# Altium schematic sheet AFE.SchDoc
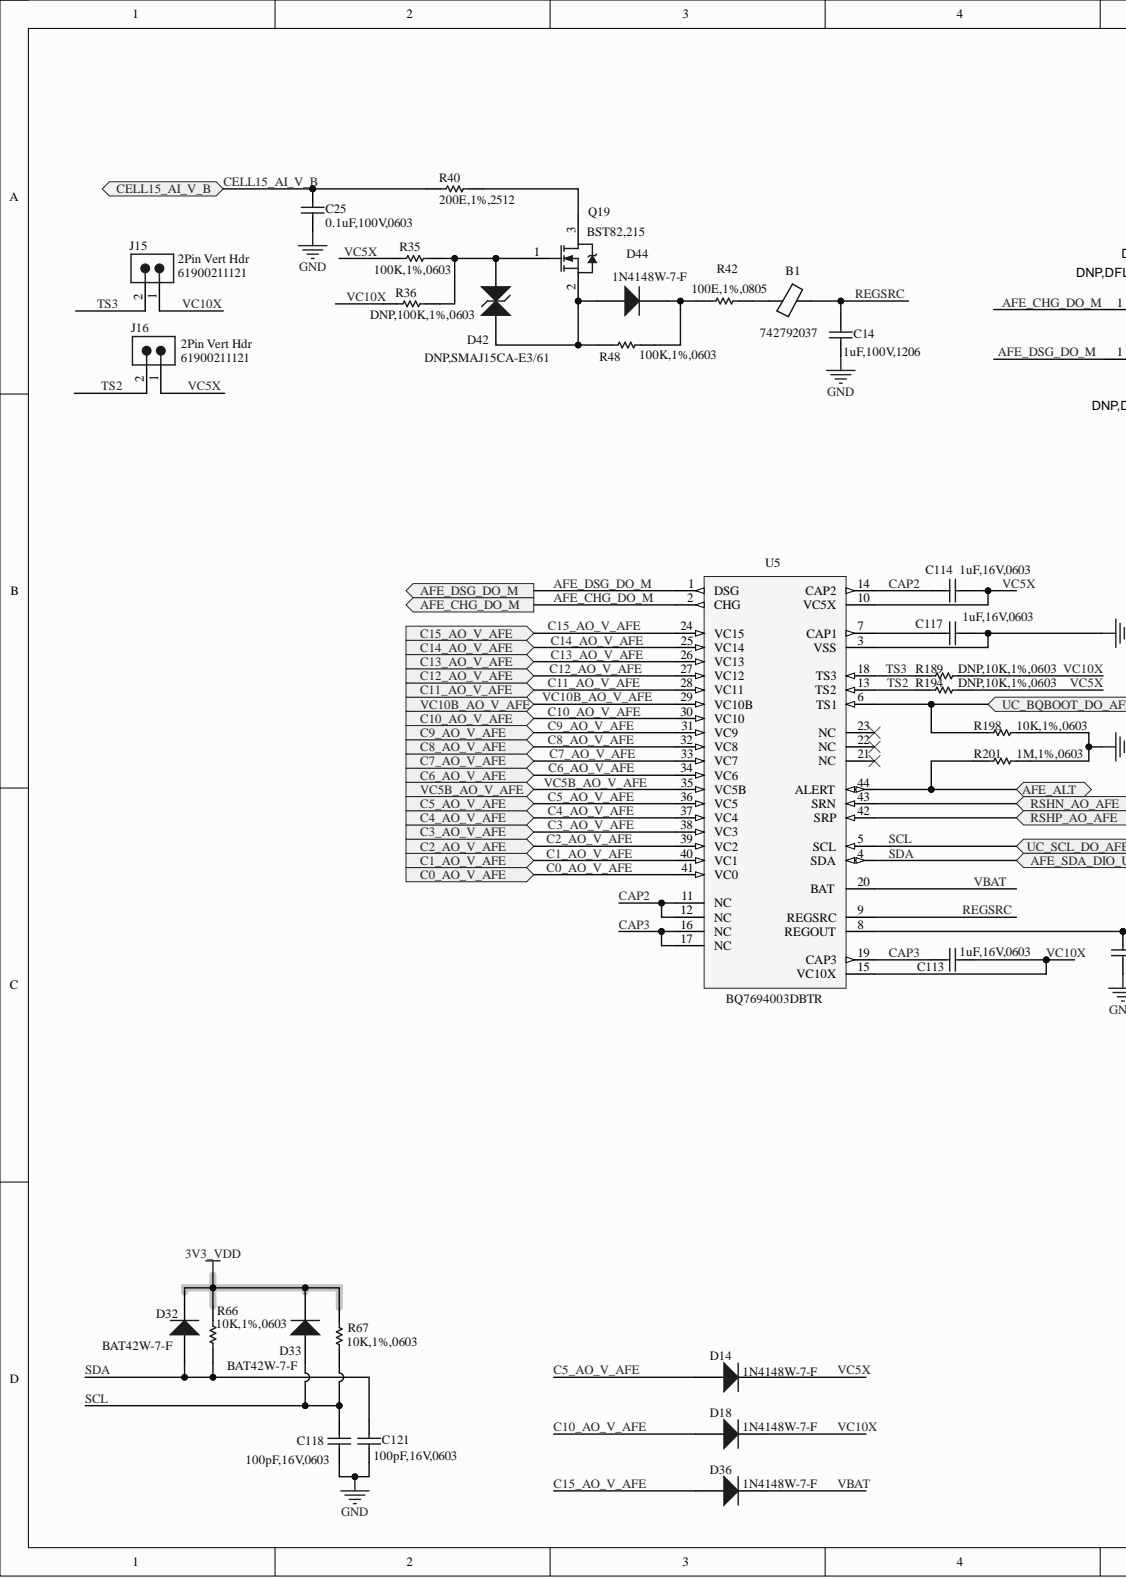
[diagram p0: AFE.SchDoc, left]
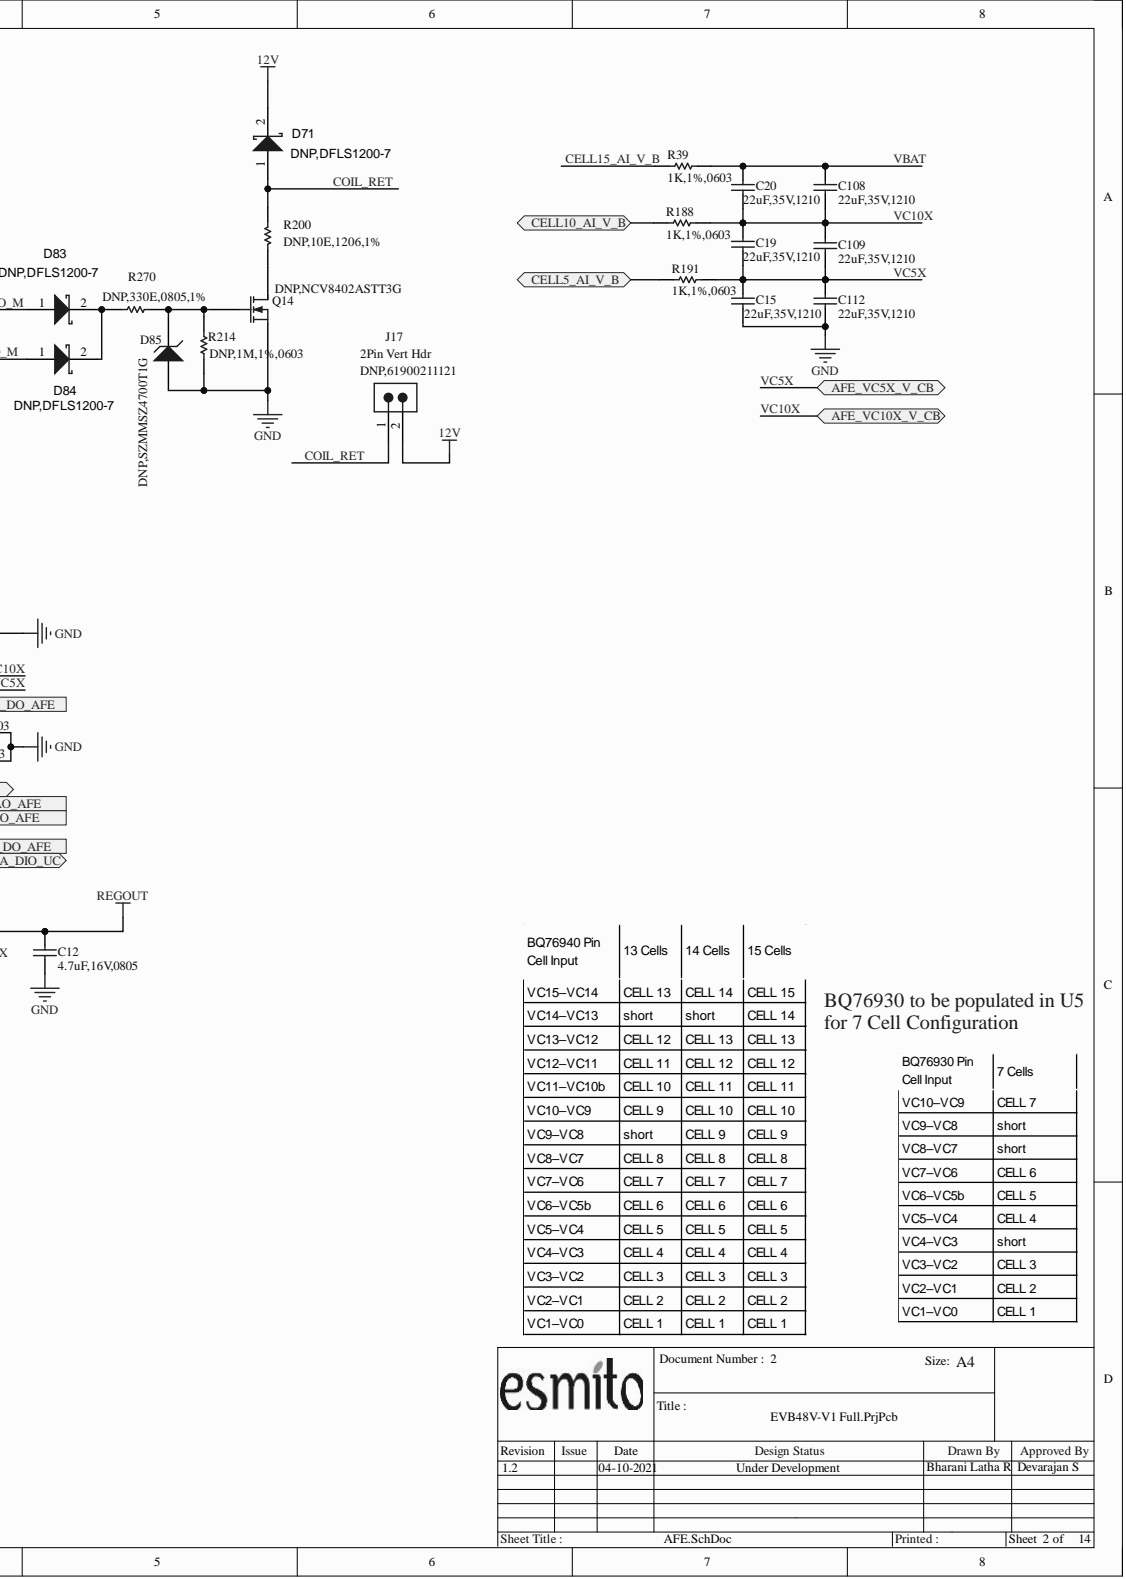
[diagram p1: AFE.SchDoc, right]

## Parts
B1  value 742792037  Part Number: 742792037  [0805_R_M]  (hidden comment: B_LowQ)
C12  value 4.7uF,16V,0805  [0805_R_M]  (hidden comment: C_Semi)
C14  value 1uF,100V,1206  [1206_C_M]  (hidden comment: C_Semi)
C15  value 22uF,35V,1210  [1210_R_M]  (hidden comment: C_Semi)
C19  value 22uF,35V,1210  [1210_R_M]  (hidden comment: C_Semi)
C20  value 22uF,35V,1210  [1210_R_M]  (hidden comment: C_Semi)
C25  value 0.1uF,100V,0603  [0603_C_M]  (hidden comment: C_Semi)
C108  value 22uF,35V,1210  [1210_R_M]  (hidden comment: C_Semi)
C109  value 22uF,35V,1210  [1210_R_M]  (hidden comment: C_Semi)
C112  value 22uF,35V,1210  [1210_R_M]  (hidden comment: C_Semi)
C113  value 1uF,16V,0603  [0603_C_M]  (hidden comment: C_Semi)
C114  value 1uF,16V,0603  [0603_C_M]  (hidden comment: C_Semi)
C117  value 1uF,16V,0603  [0603_C_M]  (hidden comment: C_Semi)
C118  value 100pF,16V,0603  [0603_C_M]  (hidden comment: C_Semi)
C121  value 100pF,16V,0603  [0603_C_M]  (hidden comment: C_Semi)
D14  value 1N4148W-7-F  Part Number: 1N4148W-7-F  [SOD_123_M]  (hidden comment: D_Standard)
D18  value 1N4148W-7-F  Part Number: 1N4148W-7-F  [SOD_123_M]  (hidden comment: D_Standard)
D32  value BAT42W-7-F  Part Number: BAT42W-7-F  [SOD_123_M]  (hidden comment: D_Standard)
D33  value BAT42W-7-F  Part Number: BAT42W-7-F  [SOD_123_M]  (hidden comment: D_Standard)
D36  value 1N4148W-7-F  Part Number: 1N4148W-7-F  [SOD_123_M]  (hidden comment: D_Standard)
D42  SMAJ15CA-E3/61  value DNP,SMAJ15CA-E3/61  [DIOM5127X229N]
D44  value 1N4148W-7-F  Part Number: 1N4148W-7-F  [SOD_123_M]  (hidden comment: D_Standard)
D71  DFLS1200-7  value DNP,DFLS1200-7  [POWERDI123]
D83  DFLS1200-7  value DNP,DFLS1200-7  [POWERDI123]
D84  DFLS1200-7  value DNP,DFLS1200-7  [POWERDI123]
D85  value DNP,SZMMSZ4700T1G  [SOD_123_M]  (hidden comment: D_Zener)
J15  2Pin Vert Hdr  value 61900211121  [61900211121]
J16  2Pin Vert Hdr  value 61900211121  [61900211121]
J17  2Pin Vert Hdr  value DNP,61900211121  [61900211121]
Q14  DNP,NCV8402ASTT3G  [SOT230P700X175-4N]
Q19  value BST82,215  Part Number: BST82,215  [SOT23_3_M]  (hidden comment: MOS-N_E_Power)
R35  value 100K,1%,0603  [0603_R_M]  (hidden comment: R_Semi)
R36  value DNP,100K,1%,0603  [0603_R_M]  (hidden comment: R_Semi)
R39  value 1K,1%,0603  [0603_R_M]  (hidden comment: R_Semi)
R40  value 200E,1%,2512  [2512_R_M]  (hidden comment: R_Semi)
R42  value 100E,1%,0805  [0805_R_M]  (hidden comment: R_Semi)
R48  value 100K,1%,0603  [0603_R_M]  (hidden comment: R_Semi)
R66  value 10K,1%,0603  [0603_R_M]  (hidden comment: R_Semi)
R67  value 10K,1%,0603  [0603_R_M]  (hidden comment: R_Semi)
R188  value 1K,1%,0603  [0603_R_M]  (hidden comment: R_Semi)
R189  value DNP,10K,1%,0603  [0603_R_M]  (hidden comment: R_Semi)
R191  value 1K,1%,0603  [0603_R_M]  (hidden comment: R_Semi)
R194  value DNP,10K,1%,0603  [0603_R_M]  (hidden comment: R_Semi)
R198  value 10K,1%,0603  [0603_R_M]  (hidden comment: R_Semi)
R200  value DNP,10E,1206,1%  [1206_C_M]  (hidden comment: R_Semi)
R201  value 1M,1%,0603  [0603_R_M]  (hidden comment: R_Semi)
R214  value DNP,1M,1%,0603  [0603_R_M]  (hidden comment: R_Semi)
R270  value DNP,330E,0805,1%  [0805_R_M]  (hidden comment: R_Semi)
U5  value BQ7694003DBTR  Part Number: BQ7694003DBTR  [TSSOP_44_M]  (hidden comment: AFE_BQ76940)

## Ports
AFE_ALT, AFE_CHG_DO_M, AFE_DSG_DO_M, AFE_SDA_DIO_UC, AFE_VC5X_V_CB, AFE_VC10X_V_CB, C0_AO_V_AFE, C1_AO_V_AFE, C2_AO_V_AFE, C3_AO_V_AFE, C4_AO_V_AFE, C5_AO_V_AFE, C6_AO_V_AFE, C7_AO_V_AFE, C8_AO_V_AFE, C9_AO_V_AFE, C10_AO_V_AFE, C11_AO_V_AFE, C12_AO_V_AFE, C13_AO_V_AFE, C14_AO_V_AFE, C15_AO_V_AFE, CELL5_AI_V_B, CELL10_AI_V_B, CELL15_AI_V_B, RSHN_AO_AFE, RSHP_AO_AFE, UC_BQBOOT_DO_AFE, UC_SCL_DO_AFE, VC5B_AO_V_AFE, VC10B_AO_V_AFE

## Nets
3V3_VDD: D32.2 D33.2 R66.1 R67.1
12V: D71.2 J17.2
GND: C12.1 C14.1 C15.1 C25.1 C112.1 C117.1 C118.1 C121.1 D85.1 Q14.3 R198.2 R201.1 R214.2 U5.3(VSS)
REGOUT: C12.2 U5.8(REGOUT)
AFE_ALT: R201.2 U5.44(ALERT) ; port AFE_ALT
AFE_CHG_DO_M: D83.1 U5.2(CHG) ; port AFE_CHG_DO_M
AFE_DSG_DO_M: D84.1 U5.1(DSG) ; port AFE_DSG_DO_M
C0_AO_V_AFE: U5.41(VC0) ; port C0_AO_V_AFE
C1_AO_V_AFE: U5.40(VC1) ; port C1_AO_V_AFE
C2_AO_V_AFE: U5.39(VC2) ; port C2_AO_V_AFE
C3_AO_V_AFE: U5.38(VC3) ; port C3_AO_V_AFE
C4_AO_V_AFE: U5.37(VC4) ; port C4_AO_V_AFE
C5_AO_V_AFE: D14.1 U5.36(VC5) ; port C5_AO_V_AFE
C6_AO_V_AFE: U5.34(VC6) ; port C6_AO_V_AFE
C7_AO_V_AFE: U5.33(VC7) ; port C7_AO_V_AFE
C8_AO_V_AFE: U5.32(VC8) ; port C8_AO_V_AFE
C9_AO_V_AFE: U5.31(VC9) ; port C9_AO_V_AFE
C10_AO_V_AFE: D18.1 U5.30(VC10) ; port C10_AO_V_AFE
C11_AO_V_AFE: U5.28(VC11) ; port C11_AO_V_AFE
C12_AO_V_AFE: U5.27(VC12) ; port C12_AO_V_AFE
C13_AO_V_AFE: U5.26(VC13) ; port C13_AO_V_AFE
C14_AO_V_AFE: U5.25(VC14) ; port C14_AO_V_AFE
C15_AO_V_AFE: D36.1 U5.24(VC15) ; port C15_AO_V_AFE
CAP2: C114.2 U5.11(NC) U5.12(NC) U5.14(CAP2)
CAP3: C113.2 U5.16(NC) U5.17(NC) U5.19(CAP3)
CELL5_AI_V_B: R191.1 ; port CELL5_AI_V_B
CELL10_AI_V_B: R188.1 ; port CELL10_AI_V_B
CELL15_AI_V_B: C25.2 R39.1 R40.1 ; port CELL15_AI_V_B
COIL_RET: D71.1 J17.1 R200.1
REGSRC: B1.2 C14.2 U5.9(REGSRC)
RSHN_AO_AFE: U5.43(SRN) ; port RSHN_AO_AFE
RSHP_AO_AFE: U5.42(SRP) ; port RSHP_AO_AFE
SCL: C118.2 D33.1 R67.2 U5.5(SCL) ; port UC_SCL_DO_AFE
SDA: C121.2 D32.1 R66.2 U5.4(SDA) ; port AFE_SDA_DIO_UC
TS2: J16.2 R194.1 U5.13(TS2)
TS3: J15.2 R189.1 U5.18(TS3)
UC_BQBOOT_DO_AFE: R198.1 U5.6(TS1) ; port UC_BQBOOT_DO_AFE
VBAT: C20.2 C108.2 D36.2 R39.2 U5.20(BAT)
VC5B_AO_V_AFE: U5.35(VC5B) ; port VC5B_AO_V_AFE
VC5X: C15.2 C19.1 C109.1 C112.2 C114.1 D14.2 J16.1 R35.1 R191.2 R194.2 U5.10(VC5X) ; port AFE_VC5X_V_CB
VC10B_AO_V_AFE: U5.29(VC10B) ; port VC10B_AO_V_AFE
VC10X: C19.2 C20.1 C108.1 C109.2 C113.1 D18.2 J15.1 R36.1 R188.2 R189.2 U5.15(VC10X) ; port AFE_VC10X_V_CB
NetB1_1: B1.1 R42.2
NetC117_2: C117.2 U5.7(CAP1)
NetD42_1: D42.1 D44.1 Q19.2 R48.1
NetD42_2: D42.2 Q19.1 R35.2 R36.2
NetD44_2: D44.2 R42.1 R48.2
NetD83_2: D83.2 D84.2 R270.1
NetD85_2: D85.2 Q14.1 R214.1 R270.2
NetQ14_2: Q14.2 R200.2
NetQ19_3: Q19.3 R40.2

Not connected: U5 21(NC) 22(NC) 23(NC)

## Library data of the parts
B1 = B_LowQ: Ferrite Bead WE-CBF 0805 SMD Bead 100MHz 330Ohm 2A; Alternate Manufacturer: Murata; Alternate Part Number: BLM21PG331SN1D; Manufacturer: Wurth Elektronik; Component Kind: Standard; Library Name: Grinntech_Global_Library.IntLib
C12 = C_Semi: MLCC - 0805 4.7uF 16V X7R 10%; Alternate Manufacturer: Murata; Alternate Part Number: GCM21BR71C475KA73K; Manufacturer: Yageo; Part Number: CC0805KKX7R7BB475; Component Kind: Standard; Signal Integrity: Cap Semi; Simulation: CAP; Library Name: Grinntech_Global_Library.IntLib
C14 = C_Semi: MLCC - 1206 1uF 100V X7R 10%; Manufacturer: Yageo; Part Number: CC1206KKX7R0BB105; Component Kind: Standard; Signal Integrity: Cap Semi; Simulation: CAP; Library Name: Grinntech_Global_Library.IntLib
C15 C19 C20 C108 C109 C112 = C_Semi: MLCC - 1210 35VDC 22uF 20% X5R; Manufacturer: Taiyo Yuden; Part Number: GMK325BJ226MM-P; Component Kind: Standard; Library Name: Grinntech_Global_Library.IntLib
C25 = C_Semi: MLCC - 0603 100nF 10% 100V; Manufacturer: Murata; Part Number: CC0603KRX7R0BB104; Component Kind: Standard; Signal Integrity: Cap Semi; Simulation: CAP; Library Name: Grinntech_Global_Library.IntLib
C113 C114 C117 = C_Semi: MLCC - 0603 1uF 16V X5R 10%; Alternate Manufacturer: Murata; Alternate Part Number: GCM188R71C105KA64D; Manufacturer: Yageo; Part Number: CC603KRX5R7BB105; Component Kind: Standard; Signal Integrity: Cap Semi; Simulation: CAP; Library Name: Grinntech_Global_Library.IntLib
C118 C121 = C_Semi: MLCC - 0603 16V 100pF X7R 10%; Manufacturer: AVX; Part Number: 0603YC101K4T2A; Component Kind: Standard; Signal Integrity: Cap Semi; Simulation: CAP; Library Name: Grinntech_Global_Library.IntLib
D14 D18 D36 D44 = D_Standard: DIODE GEN PURP 100V 300MA SOD123; Manufacturer: Diodes Incorporated; Component Kind: Standard; Signal Integrity: Diode; Simulation: DIODE; Library Name: Grinntech_Global_Library.IntLib
D32 D33 = D_Standard: Diode, Schottky, 30V, 200mA, SOD123; Manufacturer: Diodes Incorporated; Component Kind: Standard; Signal Integrity: Diode; Simulation: DIODE; Library Name: Grinntech_Global_Library.IntLib
D42 = D_TVS: ESD Suppressors / TVS Diode 400W 15V Bi; Manufacturer: Vishay; Component Kind: Standard; Part Number: SMAJ15CA-E3/61; Library Name: CLVESB-R10.SCHLIB
D71 D83 D84 = DFLS1200-7: Schottky Diode 1.0A 200V POWERDI-123; Manufacturer: Diodes Incorporated; Component Kind: Standard; Part Number: DFLS1200-7; Signal Integrity: Diode; Library Name: CLVESB-R10.SCHLIB
D85 = D_Zener: ZEN SOD123 REG 0.5W 13V; Alternate Manufacturer: ON Semiconductor; Alternate Part Number: MMSZ5V6T1G; Part Number: SZMMSZ4700T1G; Component Kind: Standard; Manufacturer: ON Semiconductor; Signal Integrity: D Zener; Simulation: ZENER; Library Name: Grinntech_Global_Library.IntLib
J15-J17 = 61900211121: WR-WTB THT Male Vertical Locking Header, pitch 2.54mm, 2p; Manufacturer: Wurth Elektronik; Component Kind: Standard; Part Number: 61900211121; Library Name: AFE_UC_Active_Conn.SchLib
Q14 = NCV8402ASTT3G: MOSFET 42V 2.0A; Manufacturer I: ON Semiconductor; Component Kind: Standard; Manufacturer Q: ON Semiconductor; Part Number Q: NCV8402ASTT3G; Value: NCV8402ASTT3G; Library Name: AFE_UC_Active_Conn.SchLib
Q19 = MOS-N_E_Power: MOSFET, N-CH, 100V, 190mA, SOT23; Manufacturer: Nexperia; Component Kind: Standard; Simulation: IRF1010; Library Name: CLVESB-R10.SCHLIB
R35 R36 R48 = R_Semi: R, THK FLM, 100K, 1%, 100mW, 0603; Alternate Manufacturer: Vishay; Alternate Part Number: CRCW0603100KFKEA; Manufacturer: Yageo; Part Number: RC0603FR-07100KL; Component Kind: Standard; Signal Integrity: Res Semi; Simulation: RES; Library Name: Grinntech_Global_Library.IntLib
R39 R188 = R_Semi: R, THK FLM, 1K, 1%, 100mW, 0603; Alternate Manufacturer: Vishay; Alternate Part Number: CRCW06031K00FKEA; Manufacturer: Yageo; Part Number: RC0603FR-071KL; Component Kind: Standard; Signal Integrity: Res Semi; Simulation: RES; Library Name: Grinntech_Global_Library.IntLib
R40 = R_Semi: R, THK FLM, 200E, 1%, 1W, 2512; Manufacturer: Yageo; Part Number: RC2512FK-07200RL; Component Kind: Standard; Signal Integrity: Res Semi; Simulation: RES; Library Name: Grinntech_Global_Library.IntLib
R42 = R_Semi: R, THK FLM, 100E, 1%, 400mW, 0805; Manufacturer: Vishay; Part Number: RCS0805100RFKEA; Component Kind: Standard; Library Name: Grinntech_Global_Library.IntLib
R66 R67 R189 R194 R198 = R_Semi: R, THK FLM, 10K, 1%, 100mW, 0603; Alternate Manufacturer: Vishay; Alternate Part Number: CRCW060310K0FKEA; Manufacturer: Yageo; Part Number: RC0603FR-0710KL; Component Kind: Standard; Signal Integrity: Res Semi; Simulation: RES; Library Name: Grinntech_Global_Library.IntLib
R191 = R_Semi: R, THK FLM, 1K, 1%, 100mW, 0603; Alternate Manufacturer: Vishay; Alternate Part Number: CRCW06031K00FKEA; Manufacturer: Yageo; Part Number: RC0603FR-071KL; Value: 1K,1%,0603; Component Kind: Standard; Signal Integrity: Res Semi; Simulation: RES; Library Name: Grinntech_Global_Library.IntLib
R200 = R_Semi: R, THK FLM, 10E, 1%, 500mW, 1206; Manufacturer: Vishay; Part Number: RCS120610R0FKEA; Component Kind: Standard; Signal Integrity: Res Semi; Simulation: RES; Library Name: Grinntech_Global_Library.IntLib
R201 R214 = R_Semi: R, THK FLM, 1M, 1%, 100mW, 0603; Manufacturer: Yageo; Part Number: RC0603FR-071ML; Component Kind: Standard; Signal Integrity: Res Semi; Simulation: RES; Library Name: Grinntech_Global_Library.IntLib
R270 = R_Semi: R, THK FLM, 330E, 1%, 400mW, 0805; Manufacturer: Vishay; Part Number: CRCW0805330RFKEA; Component Kind: Standard; Library Name: Grinntech_Global_Library.IntLib
U5 = AFE_BQ76940: IC, BQ76940-Multicell battery monitor, TSSOP; Manufacturer: Texas Instruments; Component Kind: Standard; Library Name: CLVESB-R10.SCHLIB
